# Altium schematic sheet CORE.SchDoc(CORE)
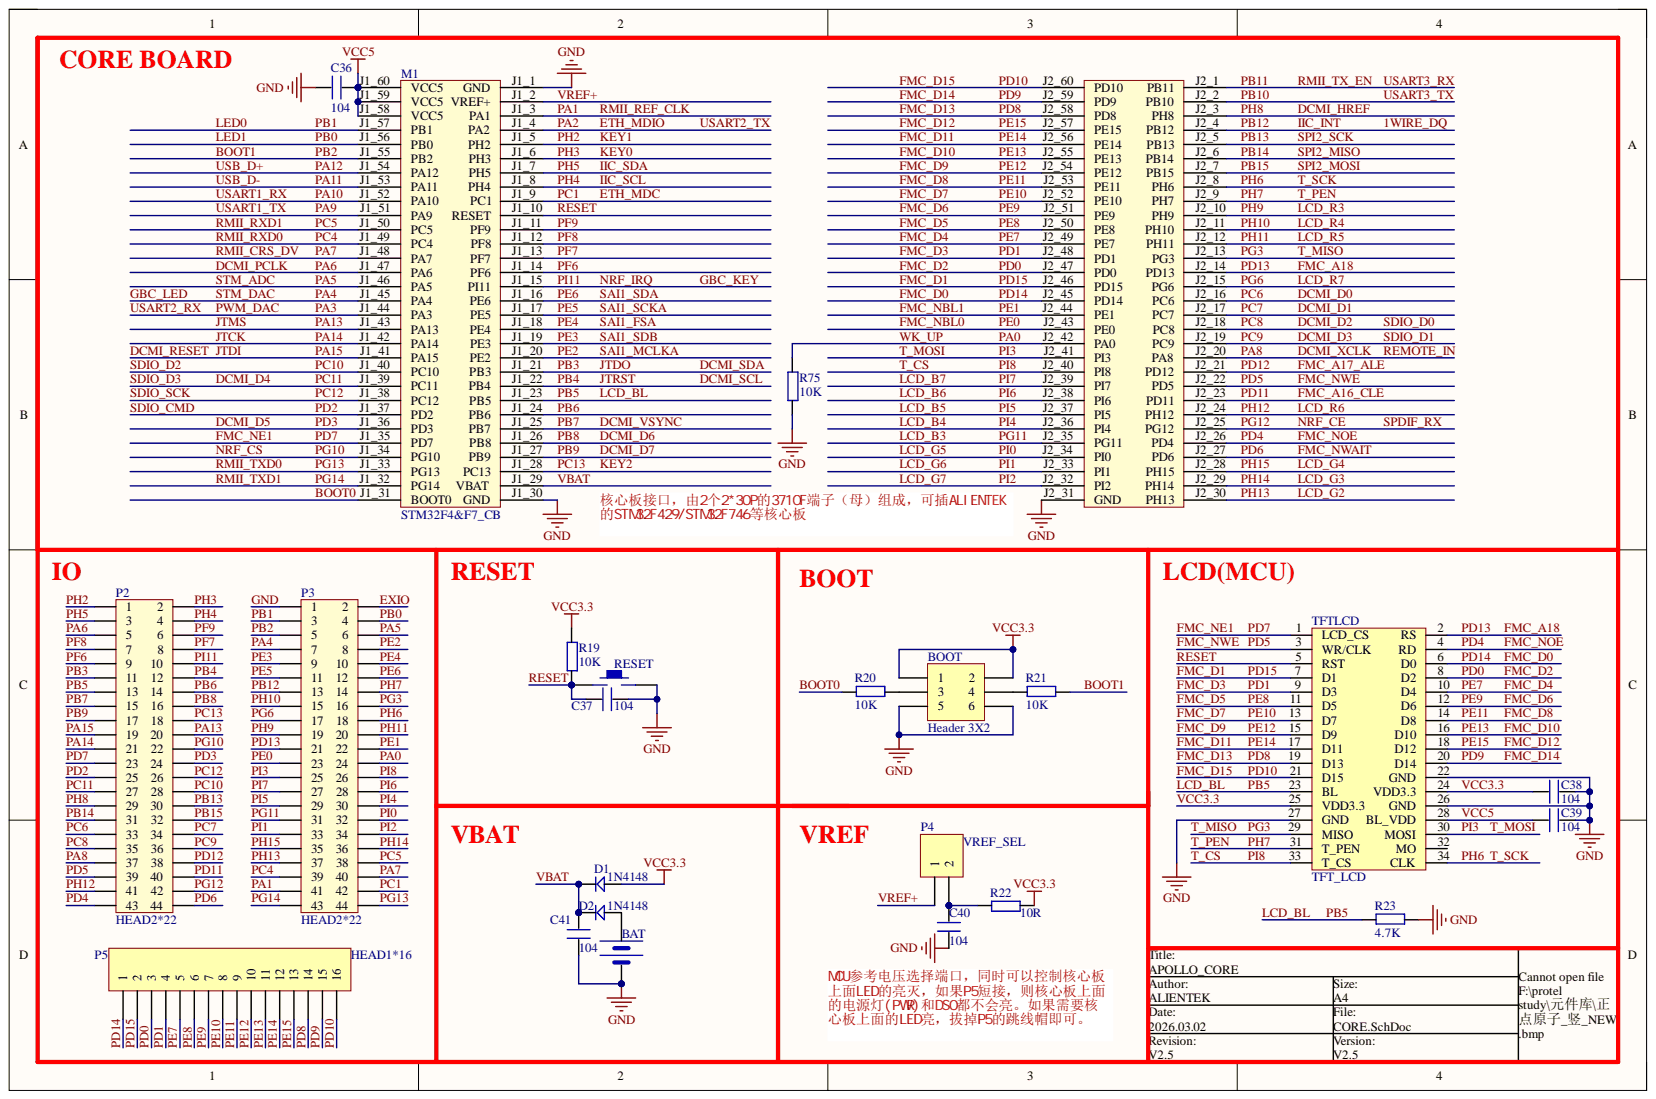
[diagram p0: CORE.SchDoc]

## Parts
BAT  BATT  [BATM]  (value not drawn)
BOOT  Header 3X2  [HDR2X3]
C36  104  [0402]
C37  104  [0402]
C38  104  [0402]
C39  104  [0402]
C40  104  [0402]
C41  104  [0402]
D1  1N4148  [DIODE_SOP]
D2  1N4148  [DIODE_SOP]
M1  STM32F4&F7_CB  [STM32F4&F7_CB]
P2  HEAD2*22  [HEAD2*22]
P3  HEAD2*22  [HEAD2*22]
P4  VREF_SEL  [HDR1X2]
P5  HEAD1*16  [HDR1X16]
R19  10K  [0402]
R20  10K  [0402]
R21  10K  [0402]
R22  10R  [0402]
R23  4.7K  [0402]
R75  10K  [0402]
RESET  KEY_M  [KEY_M]  (value not drawn)
TFTLCD  TFT_LCD  [db2*17-4.3]

## Nets
1WIRE_DQ: M1.J2_4(PB12) P3.13
BOOT0: M1.J1_31(BOOT0) R20.2
BOOT1: M1.J1_55(PB2) P3.5 R21.1
DCMI_D0: M1.J2_16(PC6) P2.33
DCMI_D1: M1.J2_17(PC7) P2.34
DCMI_D2: M1.J2_18(PC8) P2.35
DCMI_D3: M1.J2_19(PC9) P2.36
DCMI_D4: M1.J1_39(PC11) P2.27
DCMI_D5: M1.J1_36(PD3) P2.24
DCMI_D6: M1.J1_26 P2.16
DCMI_D7: M1.J1_27 P2.17
DCMI_HREF: M1.J2_3(PH8) P2.29
DCMI_PCLK: M1.J1_47(PA6) P2.5
DCMI_RESET: M1.J1_41(PA15) P2.19
DCMI_SCL: M1.J1_22 P2.12
DCMI_SDA: M1.J1_21 P2.11
DCMI_VSYNC: M1.J1_25 P2.15
DCMI_XCLK: M1.J2_20(PA8) P2.37
ETH_MDC: M1.J1_9 P3.42
ETH_MDIO: M1.J1_4
EXIO: P3.2
FMC_A16_CLE: M1.J2_23(PD11) P2.40
FMC_A17_ALE: M1.J2_21(PD12) P2.38
FMC_A18: M1.J2_14(PD13) P3.21 TFTLCD.2(RS)
FMC_D0: M1.J2_45 P5.1 TFTLCD.6(D0)
FMC_D1: M1.J2_46(PD15) P5.2 TFTLCD.7(D1)
FMC_D2: M1.J2_47 P5.3 TFTLCD.8(D2)
FMC_D3: M1.J2_48 P5.4 TFTLCD.9(D3)
FMC_D4: M1.J2_49 P5.5 TFTLCD.10(D4)
FMC_D5: M1.J2_50 P5.6 TFTLCD.11(D5)
FMC_D6: M1.J2_51 P5.7 TFTLCD.12(D6)
FMC_D7: M1.J2_52 P5.8 TFTLCD.13(D7)
FMC_D8: M1.J2_53 P5.9 TFTLCD.14(D8)
FMC_D9: M1.J2_54 P5.10 TFTLCD.15(D9)
FMC_D10: M1.J2_55 P5.11 TFTLCD.16(D10)
FMC_D11: M1.J2_56 P5.12 TFTLCD.17(D11)
FMC_D12: M1.J2_57 P5.13 TFTLCD.18(D12)
FMC_D13: M1.J2_58 P5.14 TFTLCD.19(D13)
FMC_D14: M1.J2_59 P5.15 TFTLCD.20(D14)
FMC_D15: M1.J2_60 P5.16 TFTLCD.21(D15)
FMC_NBL0: M1.J2_43 P3.23
FMC_NBL1: M1.J2_44 P3.22
FMC_NE1: M1.J1_35(PD7) P2.23 TFTLCD.1(LCD_CS)
FMC_NOE: M1.J2_26(PD4) P2.43 TFTLCD.4(RD)
FMC_NWAIT: M1.J2_27(PD6) P2.44
FMC_NWE: M1.J2_22(PD5) P2.39 TFTLCD.3(WR/CLK)
GBC_KEY: M1.J1_15(PI11) P2.10
GBC_LED: M1.J1_45(PA4) P3.7
GND: BAT.2 BOOT.5 BOOT.6 C36.1 C37.1 C38.2 C39.1 C40.1 C41.2 M1.J1_1 M1.J1_30 M1.J2_31 P3.1 R23.1 R75.1 RESET.2 TFTLCD.22(GND) TFTLCD.26(GND) TFTLCD.27(GND)
IIC_SCL: M1.J1_8 P2.4
IIC_SDA: M1.J1_7 P2.3
JTCK: M1.J1_42(PA14) P2.21
JTMS: M1.J1_43(PA13) P2.20
KEY0: M1.J1_6 P2.2
KEY1: M1.J1_5 P2.1
KEY2: M1.J1_28 P2.18
LCD_B3: M1.J2_35 P3.31
LCD_B4: M1.J2_36 P3.30
LCD_B5: M1.J2_37 P3.29
LCD_B6: M1.J2_38 P3.28
LCD_B7: M1.J2_39 P3.27
LCD_BL: M1.J1_23 P2.13 R23.2 TFTLCD.23(BL)
LCD_G2: M1.J2_30(PH13) P3.37
LCD_G3: M1.J2_29(PH14) P3.36
LCD_G4: M1.J2_28(PH15) P3.35
LCD_G5: M1.J2_34 P3.32
LCD_G6: M1.J2_33 P3.33
LCD_G7: M1.J2_32 P3.34
LCD_R3: M1.J2_10(PH9) P3.19
LCD_R4: M1.J2_11(PH10) P3.15
LCD_R5: M1.J2_12(PH11) P3.20
LCD_R6: M1.J2_24(PH12) P2.41
LCD_R7: M1.J2_15(PG6) P3.17
LED0: M1.J1_57(PB1) P3.3
LED1: M1.J1_56(PB0) P3.4
NRF_CE: M1.J2_25(PG12) P2.42
NRF_CS: M1.J1_34(PG10) P2.22
PA0: M1.J2_42 P3.24 R75.2
PA1: M1.J1_3 P3.41
PA3: M1.J1_44(PA3)
PA5: M1.J1_46(PA5) P3.6
PA7: M1.J1_48(PA7) P3.40
PA9: M1.J1_51(PA9)
PA10: M1.J1_52(PA10)
PA11: M1.J1_53(PA11)
PA12: M1.J1_54(PA12)
PB6: M1.J1_24 P2.14
PB10: M1.J2_2(PB10)
PB11: M1.J2_1(PB11)
PB13: M1.J2_5(PB13) P2.30
PB14: M1.J2_6(PB14) P2.31
PB15: M1.J2_7(PB15) P2.32
PC4: M1.J1_49(PC4) P3.39
PC5: M1.J1_50(PC5) P3.38
PC10: M1.J1_40(PC10) P2.28
PC12: M1.J1_38(PC12) P2.26
PD2: M1.J1_37(PD2) P2.25
PE2: M1.J1_20(PE2) P3.8
PE3: M1.J1_19 P3.9
PE4: M1.J1_18 P3.10
PE5: M1.J1_17 P3.11
PE6: M1.J1_16 P3.12
PF6: M1.J1_14 P2.9
PF7: M1.J1_13 P2.8
PF8: M1.J1_12 P2.7
PF9: M1.J1_11 P2.6
PG3: M1.J2_13(PG3) P3.16 TFTLCD.29(MISO)
PG13: M1.J1_33(PG13) P3.44
PG14: M1.J1_32(PG14) P3.43
PH6: M1.J2_8(PH6) P3.18 TFTLCD.34(CLK)
PH7: M1.J2_9(PH7) P3.14 TFTLCD.31(T_PEN)
PI3: M1.J2_41 P3.25 TFTLCD.30(MOSI)
PI8: M1.J2_40 P3.26 TFTLCD.33(T_CS)
RESET: C37.2 M1.J1_10 R19.1 RESET.1 TFTLCD.5(RST)
VBAT: C41.1 D1.2 D2.2 M1.J1_29
VCC3.3: BOOT.1 BOOT.2 C38.1 D1.1 R19.2 R22.2 TFTLCD.24(VDD3.3) TFTLCD.25(VDD3.3)
VCC5: C36.2 C39.2 M1.J1_58(VCC5) M1.J1_59(VCC5) M1.J1_60(VCC5) TFTLCD.28(BL_VDD)
VREF+: M1.J1_2 P4.1
NetBAT_1: BAT.1 D2.1
NetBOOT_3: BOOT.3 R20.1
NetBOOT_4: BOOT.4 R21.2
NetC40_2: C40.2 P4.2 R22.1

Not connected: TFTLCD 32(MO)

## Library data of the parts
BAT = BAT: Component Kind: Standard; Library Name: MYCOMLIB.IntLib
BOOT = Header 3X2: Header, 3-Pin, Dual row; Component Kind: Standard; LatestRevisionDate: 17-Jul-2002; LatestRevisionNote: Re-released for DXP Platform.; Library Name: Miscellaneous Connectors.IntLib; Publisher: Altium Limited
C36-C41 = C: Component Kind: Standard
  C36: Library Name: ALIENTEK_COMPONENT.IntLib
  C37-C41: Library Name: MYCOMLIB.IntLib
D1 D2 = D: Component Kind: Standard; Library Name: MYCOMLIB.IntLib
M1 = STM32F4&F7_CB: F429/746 Core Board; Component Kind: Standard; Library Name: ALIENTEK_COMPONENT.IntLib
P4 = Header 2: Header, 2-Pin; Component Kind: Standard; LatestRevisionDate: 17-Jul-2002; LatestRevisionNote: Re-released for DXP Platform.; Library Name: Miscellaneous Connectors.IntLib; Publisher: Altium Limited
P5 = Header 16: Header, 16-Pin; Component Kind: Standard; LatestRevisionDate: 17-Jul-2002; LatestRevisionNote: Re-released for DXP Platform.; Library Name: Miscellaneous Connectors.IntLib; Signal Integrity: Connector; Publisher: Altium Limited
R19-R23 R75 = R: Component Kind: Standard; Library Name: MYCOMLIB.IntLib
P2 P3 = HEAD2*22: Component Kind: Standard; Library Name: ALIENTEK_COMPONENT.IntLib
RESET = KEY_M: Component Kind: Standard; Library Name: ALIENTEK_COMPONENT.IntLib
TFTLCD = TFT_LCD: Component Kind: Standard; Library Name: ALIENTEK_COMPONENT.IntLib
